# Altium schematic sheet PSU + RTC.SchDoc("PSU + RTC")
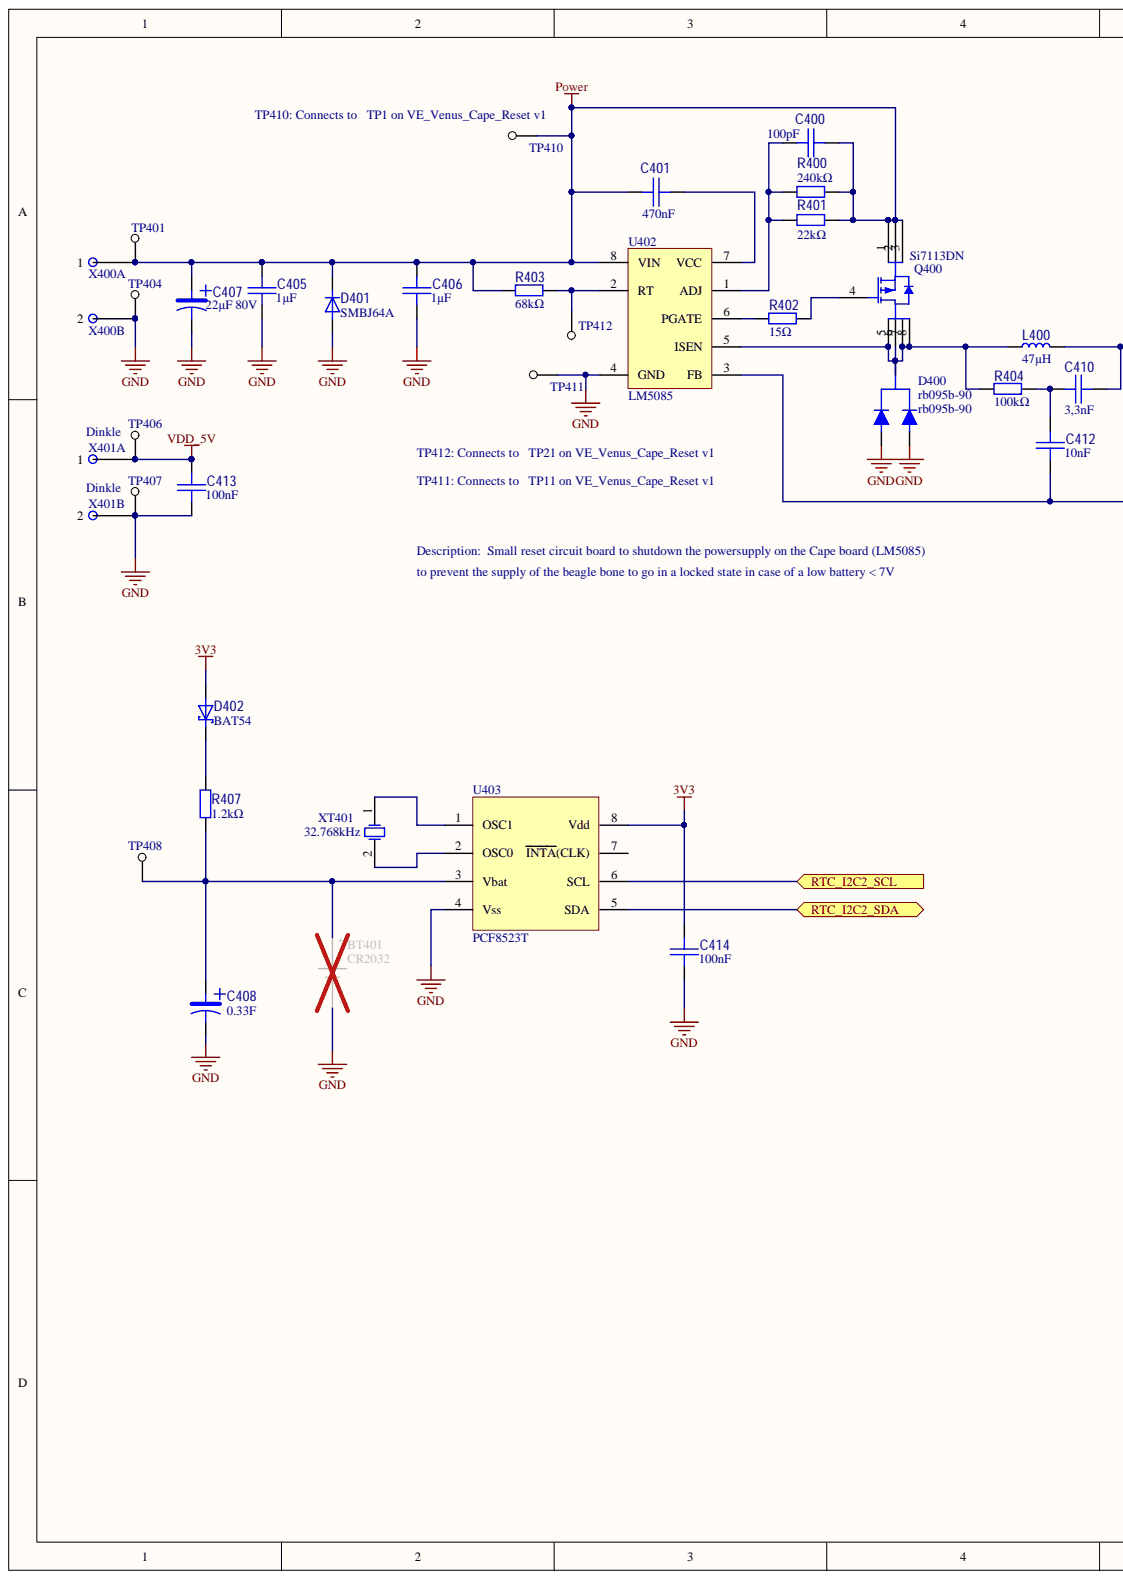
[diagram p0: PSU + RTC.SchDoc, left]
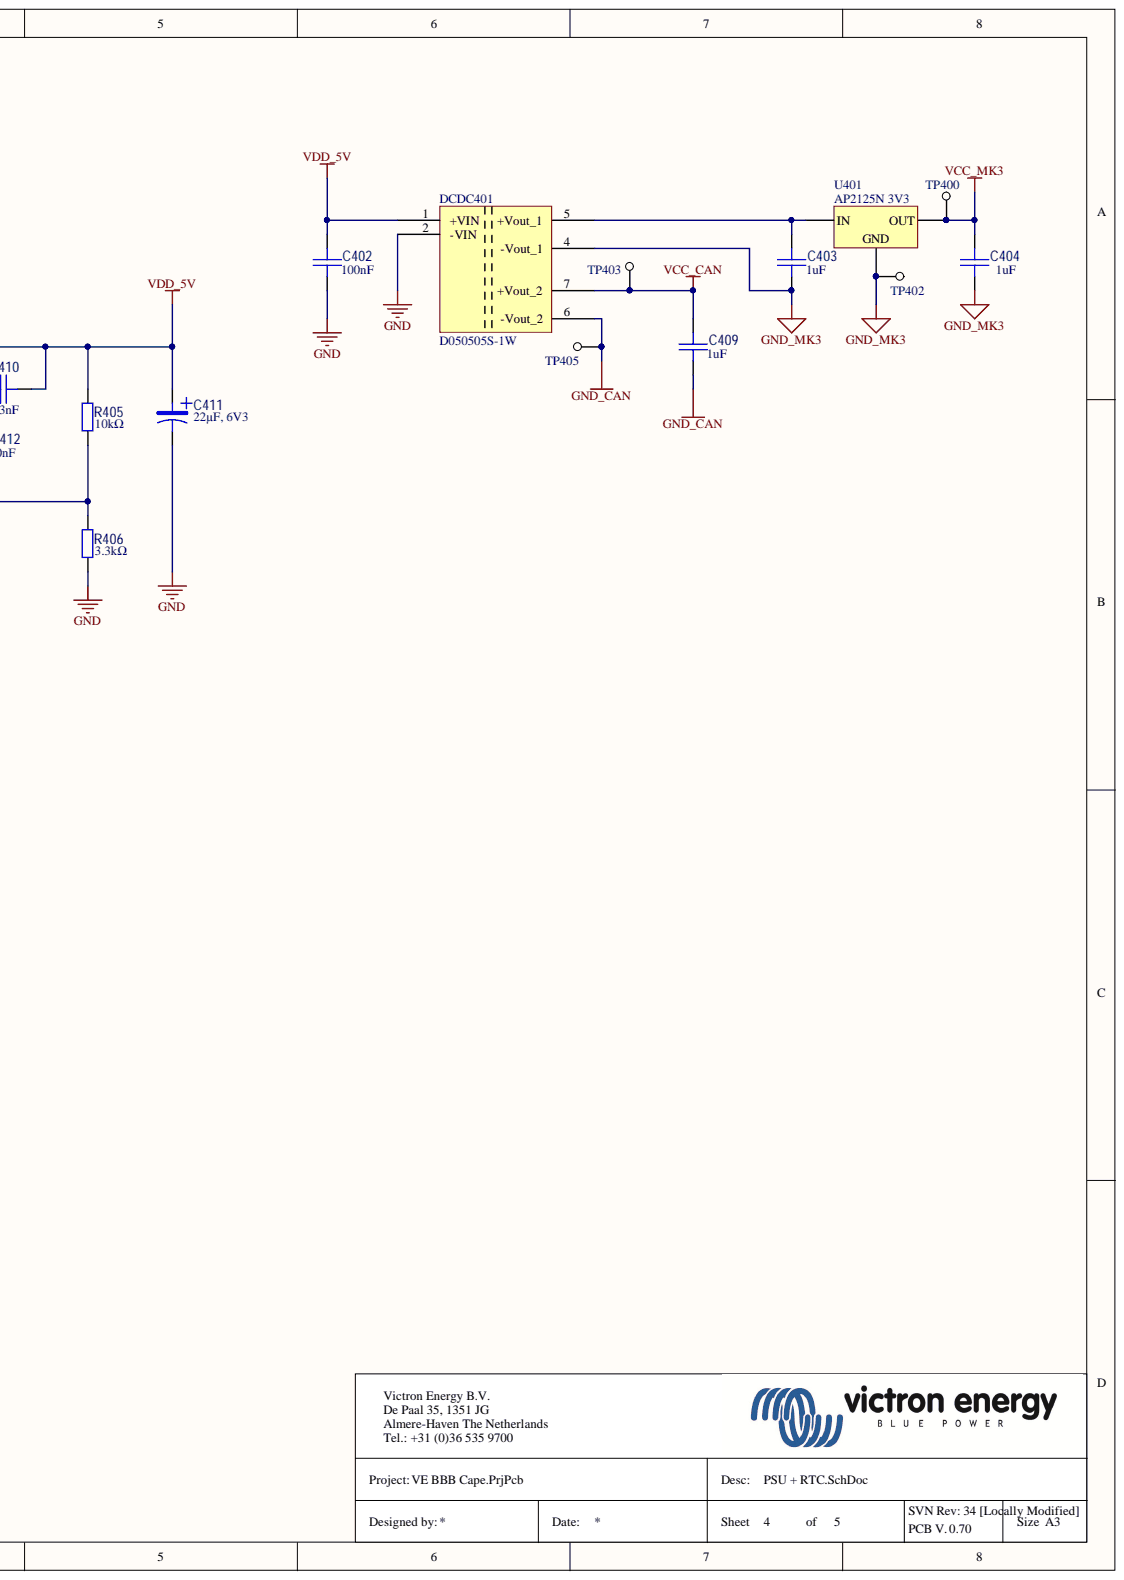
[diagram p1: PSU + RTC.SchDoc, right]

## Parts
BT401  CR2032  [CR2032HFN]
C400  value 100pF  [C0805_R]  (hidden comment: 100419)
C401  value 470nF  [C0805_R]  (hidden comment: 1044)
C402  value 100nF  [C0805_R]  (hidden comment: 1035)
C403  value 1uF  [C0805_R]  (hidden comment: 100185)
C404  value 1uF  [C0805_R]  (hidden comment: 100185)
C405  value 1µF  [C1206_R]  (hidden comment: 100237)
C406  value 1µF  [C1206_R]  (hidden comment: 100237)
C407  value 22µF 80V  [ELCO_8X10_V]  (hidden comment: 100401)
C408  value 0.33F  [033F5V10x5]  (hidden comment: 101670)
C409  value 1uF  [C0805_R]  (hidden comment: 100185)
C410  value 3,3nF  [C0805_R]  (hidden comment: 1082)
C411  value 22µF, 6V3  [ELCO_6.3X5.8_V]  (hidden comment: 100402)
C412  value 10nF  [C0805_R]  (hidden comment: 100420)
C413  value 100nF  [C0805_R]  (hidden comment: 1035)
C414  value 100nF  [C0805_R]  (hidden comment: 1035)
D400  rb095b-90  [TO252_R]
D401  value SMBJ64A  [SMB/DO-214AA]  (hidden comment: 4014)
D402  BAT54  [SOT23_R]
DCDC401  value D050505S-1W  [Recom SIP DCDC 1W]  (hidden comment: D_S-1W)
L400  value 47µH  [WE-PD-XXL]  (hidden comment: 101828)
Q400  Si7113DN  [PowerPak 1212-8]
R400  value 240kΩ  [R0805_R]  (hidden comment: 429)
R401  value 22kΩ  [R0805_R]  (hidden comment: 404)
R402  value 15Ω  [R0805_R]  (hidden comment: 328)
R403  value 68kΩ  [R0805_R]  (hidden comment: 416)
R404  value 100kΩ  [R0805_R]  (hidden comment: 420)
R405  value 10kΩ  [R0805_R]  (hidden comment: 396)
R406  value 3.3kΩ  [R0805_R]  (hidden comment: 384)
R407  value 1.2kΩ  [R0805_R]  (hidden comment: 374)
TP400  CN1  [testpad_round_1mm]  (value not drawn)  (no BOM)
TP401  CN1  [testpad_round_1mm]  (value not drawn)  (no BOM)
TP402  CN1  [testpad_round_1mm]  (value not drawn)  (no BOM)
TP403  CN1  [testpad_round_1mm]  (value not drawn)  (no BOM)
TP404  CN1  [testpad_round_1mm]  (value not drawn)  (no BOM)
TP405  CN1  [testpad_round_1mm]  (value not drawn)  (no BOM)
TP406  CN1  [testpad_round_1mm]  (value not drawn)  (no BOM)
TP407  CN1  [testpad_round_1mm]  (value not drawn)  (no BOM)
TP408  CN1  [testpad_round_1mm]  (value not drawn)  (no BOM)
TP410  CN1  [testpad_round_1mm]  (value not drawn)  (no BOM)
TP411  CN1  [testpad_round_1mm]  (value not drawn)  (no BOM)
TP412  CN1  [testpad_round_1mm]  (value not drawn)  (no BOM)
U401  value AP2125N 3V3  [SOT23_R]  (hidden comment: regulator)
U402  LM5085  [HVSSOP-8]
U403  PCF8523T  [SO8_R]
X400  pluggable terminal 2P  [2EHDRC-2P]  (value not drawn)  (parts A,B)
X401  value Dinkle  [ECH350R-2P]  (hidden comment: 2 pin terminal)  (parts A,B)
XT401  32.768kHz  [ABRA-ABS07-2_V]

## Ports
RTC_I2C2_SCL, RTC_I2C2_SDA

## Nets
3V3: C414.1 D402.1 U403.8(Vdd)
GND: BT401.1 C402.2 C405.2 C406.2 C407.2 C408.2 C411.2 C413.2 C414.2 D400.1 D400.3 D401.2 DCDC401.2(-VIN) R406.2 TP404.1 TP407.1 TP411.1 U402.4(GND) U403.4(Vss) X400.2 X401.2
GND_CAN: C409.2 DCDC401.6(-Vout_2) TP405.1
GND_MK3: C403.2 C404.2 DCDC401.4(-Vout_1) TP402.1 U401.1
Power: C400.2 C401.1 C405.1 C406.1 C407.1 D401.1 Q400.1 Q400.2 Q400.3 R400.2 R401.2 R403.2 TP401.1 TP410.1 U402.8(VIN) X400.1
VCC_CAN: C409.1 DCDC401.7(+Vout_2) TP403.1
VCC_MK3: C404.1 TP400.1 U401.2
VDD_5V: C402.1 C410.2 C411.1 C413.1 DCDC401.1(+VIN) L400.1 R405.1 TP406.1 X401.1
RTC_I2C2_SCL: U403.6(SCL) ; port RTC_I2C2_SCL
RTC_I2C2_SDA: U403.5(SDA) ; port RTC_I2C2_SDA
NetBT401_0: BT401.0 C408.1 R407.1 TP408.1 U403.3(Vbat)
NetC400_1: C400.1 R400.1 R401.1 U402.1(ADJ)
NetC401_2: C401.2 U402.7(VCC)
NetC403_1: C403.1 DCDC401.5(+Vout_1) U401.3
NetC410_1: C410.1 C412.2 R404.2
NetC412_1: C412.1 R405.2 R406.1 U402.3(FB)
NetD400_4: D400.4 L400.2 Q400.5 Q400.6 Q400.7 Q400.8 R404.1 U402.5(ISEN)
NetD402_3: D402.3 R407.2
NetQ400_4: Q400.4 R402.1
NetR402_2: R402.2 U402.6(PGATE)
NetR403_1: R403.1 TP412.1 U402.2(RT)
NetU403_1: U403.1(OSC1) XT401.1
NetU403_2: U403.2(OSC0) XT401.2

Not connected: U403 7(INTA(CLK))

## Library data of the parts
BT401 = 101562: CR2032 solder pins; MANUFACTURER: Panasonic; Database Table Name: Mechanical; Cls: MECH; Value: CR2032; Supplier Price 1: 0,608; Supplier Part Number 1: P666-ND; Supplier 1: Digikey; PartID: 101562; Part: 101562; MAN_PARTNUMBER: CR2032HFN; Component Kind: Standard; Library Name: VictronAltiumComponents.SVNDBLib
C400 = 100419: Part_Description: CAP,100PF,100V,0805,NP0; MANUFACTURER: KEMET; MAN_PARTNUMBER: C0805C101J1GACTU; Voltage: 100V; VENDOR_STOCK_NR: 399-1123-2-ND; VENDOR_PRICE: 0.01426; VENDOR: Digikey; Tol: 0.05; Shape: 805; Database Table Name: COMPDATA$; Cls: CAP; Part: 100419; Component Kind: Standard; Library Name: Data Base Librarys.DbLib
C401 = 1044: Part_Description: Cap, Smd Ml.Ceramic 0805 470NF 10% 50V X7R, Yageo; MANUFACTURER: Yageo; MAN_PARTNUMBER: CC0805KKX7R9BB474; INDIC_PARTNO: CSEC470NFH0KFYAY; Voltage: 50V; VENDOR_STOCK_NR: 311-1889-1-ND; VENDOR_PRICE: 0.05409; VENDOR: Digikey; Tol: 0.1; Shape: 805; INDIC_PRICE: 0.0315; Database Table Name: COMPDATA$; Cls: CAP; Part: 1044; Component Kind: Standard; Library Name: Data Base Librarys.DbLib
C402 C413 C414 = 1035: Cap, SMD 0805, ceramic, X7R, 100nF, 50V, 10%, Yageo; MAN_PARTNUMBER: CC0805KPX7R9BB104; INDIC_PARTNO: CSE100NF0805YAGY; Tol: 10,00%; Shape: 805; INDIC_PRICE: 0,068; Database Table Name: AllComponents; Voltage: 50V; Supplier Price 1: 0,005; Supplier Part Number 1: 603-CC805KPX7R9BB104; Supplier 1: Mouser; PartID: 1035; Part: 1035; MANUFACTURER: Yageo; Component Kind: Standard; Cls: CAP; Library Name: VictronAltiumComponents.SVNDBLib
C403 C404 C409 = 100185: Part_Description: Cap, MLCC, X7R, 16V, 10%, 0805, 1uF; MANUFACTURER: Kemet; MAN_PARTNUMBER: C0805C105K4RACTU; VENDOR_STOCK_NR: 399-1284-1-ND; VENDOR_PRICE: 0.0222; VENDOR: Digikey; Database Table Name: COMPDATA$; Cls: CAP; Part: 100185; Component Kind: Standard; Library Name: Data Base Librarys.DbLib
C405 C406 = 100237: Part_Description: CAP, MLCC, X7R, 1UF, 100V, 1206; MANUFACTURER: Kemet; MAN_PARTNUMBER: C1206C105K1RACTU; VENDOR_STOCK_NR: 399-11683-1-ND; VENDOR_PRICE: 0.13915; VENDOR: Digikey; Shape: 1206; Database Table Name: COMPDATA$; Cls: CAP; Part: 100237; Component Kind: Standard; Library Name: Data Base Librarys.DbLib
C407 = 100401: Part_Description: Cap polarized, hybrid polymer. 80V 22µF; MANUFACTURER: Panasonic; MAN_PARTNUMBER: EEH-ZA1K220P; VENDOR_STOCK_NR: 667-EEH-ZA1K220P; VENDOR_PRICE: 0.958; VENDOR: Mouser; Database Table Name: COMPDATA$; Cls: CAP; Part: 100401; Component Kind: Standard; Library Name: Data Base Librarys.DbLib
C408 = 101670: Cap, Super cap, 0.33F; MANUFACTURER: Panasonic; MAN_PARTNUMBER: EECS0HD334V; Database Table Name: Capacitor; Supplier Price 1: 0,46; Shape: 033F5V10x5; PartID: 101670; Part: 101670; Component Kind: Standard; Cls: CAP; Library Name: VictronAltiumComponents.SVNDBLib
C410 = 1082: Part_Description: CAP,3.3nF,100V, 0805; MANUFACTURER: Yageo; MAN_PARTNUMBER: C0805KRX7R0BB332; INCAP_PARTNO: 1005520681; VENDOR_STOCK_NR: 311-1471-2-ND; VENDOR_PRICE: 0.01802; VENDOR: Digikey; Shape: 805; INCAP_PRICE: 0.0032; Database Table Name: COMPDATA$; Cls: CAP; Part: 1082; Component Kind: Standard; Library Name: Data Base Librarys.DbLib
C411 = 100402: Part_Description: Cap polarized, polymer. 6.3V 220µF; MANUFACTURER: Panasonic; MAN_PARTNUMBER: 6SVP220M; VENDOR_STOCK_NR: 667-6SVP220M; VENDOR_PRICE: 0.339; VENDOR: Mouser; Database Table Name: COMPDATA$; Cls: CAP; Part: 100402; Component Kind: Standard; Library Name: Data Base Librarys.DbLib
C412 = 100420: Part_Description: CAP,10nF,MLC X7R 50V,0805; MANUFACTURER: KEMET; MAN_PARTNUMBER: C0805C101K5RACTU; Voltage: 50V; VENDOR_STOCK_NR: 399-7981-2-ND; VENDOR_PRICE: 0.038; VENDOR: Digikey; Tol: 0.1; Shape: 805; Database Table Name: COMPDATA$; Cls: CAP; Part: 100420; Component Kind: Standard; Library Name: Data Base Librarys.DbLib
D400 = 101551: MANUFACTURER: Rohm; Shape: TO 252 Dpak; Database Table Name: AllComponents; Cls: DIO; Value: rb095b-90; Supplier Price 1: 0,3598; Supplier Part Number 1: RB095B-90TLTR-ND; Supplier 1: Digikey; PartID: 101551; Part: 101551; MAN_PARTNUMBER: rb095b-90; Description: rb095b-90; Component Kind: Standard; Library Name: VictronAltiumComponents.SVNDBLib
D401 = 4014: Part_Description: Diode,SMD ,2A 100V, ES2B, SMB; MANUFACTURER: Vishay; MAN_PARTNUMBER: ES2B; VENDOR_STOCK_NR: 9549250; VENDOR_PRICE: 0.154; VENDOR: Farnell; Database Table Name: COMPDATA$; Cls: DIO; Part: 4014; Component Kind: Standard; Library Name: Data Base Librarys.DbLib
D402 = 4002: Part_Description: DIODE,30V 0.2A,SOT23DDCK,BAT54; MANUFACTURER: NXP; INDIC_PARTNO: DDSMDSOTBAT54NXY; VENDOR_STOCK_NR: 1081190; VENDOR_PRICE: 0.034; VENDOR: Farnell; INDIC_PRICE: 0.0806; Database Table Name: COMPDATA$; Cls: DIO; Value: BAT54; Part: 4002; MAN_PARTNUMBER: BAT54; Component Kind: Standard; Library Name: Data Base Librarys.DbLib
DCDC401 = 101516: IC, controller, buck, 5V, 100mA, Mornsun unregulated twin DC-DC Converter; Shape: TH; Database Table Name: AllComponents; Supplier Price 1: 2,28; PartID: 101516; Part: 101516; MANUFACTURER: Mornsun; MAN_PARTNUMBER: D050505S-1W; Component Kind: Standard; Cls: IC; Library Name: VictronAltiumComponents.SVNDBLib
L400 = 101828: Choke SMD 47µH; MANUFACTURER: Bourns; MAN_PARTNUMBER: SRR1210A-470M; Shape: TH; Database Table Name: Inductor; Cls: IND; Supplier Price 1: 0,51870; Supplier Part Number 1: SRR1210A-470MCT-ND; Supplier 1: Digikey; PartID: 101828; Part: 101828; Component Kind: Standard; Library Name: VictronAltiumComponents.SVNDBLib
Q400 = 100400: Part_Description: PMOS, SI7113DN, -100V 134mOhm, PowerPAK SO8; MANUFACTURER: Vishay Siliconix; MAN_PARTNUMBER: SI7113DN-T1-GE3; VENDOR_STOCK_NR: 781-SI7113DN-T1-GE3; VENDOR_PRICE: 0.669; VENDOR: Mouser; Database Table Name: COMPDATA$; Cls: FET; Value: Si7113DN; Part: 100400; Component Kind: Standard; Library Name: Data Base Librarys.DbLib
R400 = 429: Part_Description: RES,MF,240K, 0.125W 1% 0805; MANUFACTURER: YAGEO; MAN_PARTNUMBER: RC0805FR-07240KL; VENDOR_STOCK_NR: 603-RC0805FR-07240KL; VENDOR_PRICE: 0.003; VENDOR: Mouser; Tol: 0.01; Shape: 805; Power: 0,125W; INCAP_PRICE: 0.0014; Database Table Name: COMPDATA$; Cls: RES; Part: 429; ComponentLink1Description: \datasheets\Yageo-RC0805FR-0710KL-datasheet.pdf; Component Kind: Standard; Library Name: Data Base Librarys.DbLib
R401 = 404: Part_Description: RES,MF,22K   0.125W 1% 0805; MANUFACTURER: YAGEO; MAN_PARTNUMBER: RC0805FR-0722KL; INDIC_PARTNO: RSCEE0022KF97MUY; INCAP_PARTNO: 1035031293; VENDOR_STOCK_NR: 603-RC0805FR-0722KL; VENDOR_PRICE: 0.003; VENDOR: Mouser; Tol: 0.01; Shape: 805; Power: 0,125W; INDIC_PRICE: 0.0023; INCAP_PRICE: 0.0014; Database Table Name: COMPDATA$; Cls: RES; Part: 404; ComponentLink1Description: \datasheets\Yageo-RC0805FR-0710KL-datasheet.pdf; Component Kind: Standard; Library Name: Data Base Librarys.DbLib
R402 = 328: Res, SMD 0805, MF, 15E, 0.125W 1% 0805; MANUFACTURER: YAGEO; Tol: 1,00%; Shape: 0805; Power: 0,125W; INCAP_PRICE: 0,0009; Database Table Name: Resistor; PartID: 328; Part: 328; ComponentLink1Description: \datasheets\Yageo-RC0805FR-0710KL-datasheet.pdf; Component Kind: Standard; Cls: RES; Library Name: VictronAltiumComponents.SVNDBLib
R403 = 416: Part_Description: RES,MF,68K, 0.125W 1% 0805; MANUFACTURER: YAGEO; MAN_PARTNUMBER: RC0805FR-0768KL; INDIC_PARTNO: RSCE68KXXFB8NYX; VENDOR_STOCK_NR: 603-RC0805FR-0768KL; VENDOR_PRICE: 0.003; VENDOR: Mouser; Tol: 0.01; Shape: 805; Power: 0,125W; INDIC_PRICE: 0.0018; INCAP_PRICE: 0.0014; Database Table Name: COMPDATA$; Cls: RES; Part: 416; ComponentLink1Description: \datasheets\Yageo-RC0805FR-0710KL-datasheet.pdf; Component Kind: Standard; Library Name: Data Base Librarys.DbLib
R404 = 420: Res, SMD 0805, MF, 100K 0.125W 1% 0805; MANUFACTURER: YAGEO; MAN_PARTNUMBER: RC0805FR-07 100KL; INDIC_PARTNO: RSCE100KXFB8NYX; INCAP_PARTNO: 1035031298; Tol: 1,00%; Shape: 0805; Power: 0,125W; INDIC_PRICE: 0,0008; INCAP_PRICE: 0,0009; Database Table Name: Resistor; PartID: 420; Part: 420; ComponentLink1Description: \datasheets\Yageo-RC0805FR-0710KL-datasheet.pdf; Component Kind: Standard; Cls: RES; Library Name: VictronAltiumComponents.SVNDBLib
R405 = 396: Part_Description: RES,MF,10K  0.125W 1%0805; MANUFACTURER: YAGEO; MAN_PARTNUMBER: RC0805FR-0710KL; INDIC_PARTNO: RSCE00010KFB7YAY; INCAP_PARTNO: 1035031290; VENDOR_STOCK_NR: 603-RC0805FR-0710KL; VENDOR_PRICE: 0.003; VENDOR: Mouser; Tol: 0.01; Shape: 805; Power: 0,125W; INDIC_PRICE: 0.0018; INCAP_PRICE: 0.0014; Database Table Name: COMPDATA$; Cls: RES; Part: 396; ComponentLink1Description: \datasheets\Yageo-RC0805FR-0710KL-datasheet.pdf; Component Kind: Standard; Library Name: Data Base Librarys.DbLib
R406 = 384: Part_Description: RES,MF,3K3, 0.125W 1% 0805; MANUFACTURER: YAGEO; MAN_PARTNUMBER: RC0805FR-073K3L; INDIC_PARTNO: RSCEO3K300FB6YAY; VENDOR_STOCK_NR: 603-RC0805FR-073K3L; VENDOR_PRICE: 0.003; VENDOR: Mouser; Tol: 0.01; Shape: 805; Power: 0,125W; INDIC_PRICE: 0.0076; INCAP_PRICE: 0.0014; Database Table Name: COMPDATA$; Cls: RES; Part: 384; ComponentLink1Description: \datasheets\Yageo-RC0805FR-0710KL-datasheet.pdf; Component Kind: Standard; Library Name: Data Base Librarys.DbLib
R407 = 374: Part_Description: RES,MF,1k2, 0.125W 1% 0805; MANUFACTURER: YAGEO; MAN_PARTNUMBER: RC0805FR-071K2L; VENDOR_STOCK_NR: 603-RC0805FR-071K2L; VENDOR_PRICE: 0.003; VENDOR: Mouser; Tol: 0.01; Shape: 805; Power: 0,125W; INCAP_PRICE: 0.0014; Database Table Name: COMPDATA$; Cls: RES; Part: 374; ComponentLink1Description: \datasheets\Yageo-RC0805FR-0710KL-datasheet.pdf; Component Kind: Standard; Library Name: Data Base Librarys.DbLib
TP400-TP408 TP410-TP412 = 100320: Testpad, Round, D=1mm; Database Table Name: Connector; Cls: CON; PartID: 100320; Part: 100320; Library Name: VictronAltiumComponents.SVNDBLib
U401 = 100431: Part_Description: LDO Regulator 3V3; MANUFACTURER: Diodes Inc.; MAN_PARTNUMBER: AP2125N-3.3TRG1; VENDOR_STOCK_NR: 621-AP2125N-3.3TRG1; VENDOR_PRICE: 0.077; VENDOR: Mouser; Database Table Name: COMPDATA$; Cls: IC; Part: 100431; Component Kind: Standard; Library Name: Data Base Librarys.DbLib
U402 = 101556: IC, controller, buck, 75V; MANUFACTURER: TI; MAN_PARTNUMBER: LM5085MY/NOPB; Value: LM5085; Supplier Price 1: 0,95625; Supplier Part Number 1: LM5085MY/NOPBCT-ND; Supplier 1: Digikey; Shape: HVSSOP-8; PartID: 101556; Part: 101556; Database Table Name: IC; Component Kind: Standard; Cls: IC; Library Name: VictronAltiumComponents.SVNDBLib
U403 = 101555: IC, RTC, I2C; MANUFACTURER: NXP; Shape: SOIC8; Database Table Name: AllComponents; Value: PCF8523T; Supplier Price 1: 0,58590; Supplier Part Number 1: 568-5306-1-ND; Supplier 1: Digikey; PartID: 101555; Part: 101555; MAN_PARTNUMBER: PCF8523T; Component Kind: Standard; Cls: IC; Library Name: VictronAltiumComponents.SVNDBLib
X400 = 101569: 2EHDRC-2P; MANUFACTURER: 2EHDRC-2P + 2ESDV-2P; Database Table Name: AllComponents; Cls: CON; PartID: 101569; Part: 101569; Component Kind: Standard; Library Name: VictronAltiumComponents.SVNDBLib
X401 = 100409: Part_Description: 2 pin Pluggable terminal Dinkle ECH350R; VENDOR_PRICE: 0.4261; VENDOR: ASTA TECHNOLOGIES; Database Table Name: COMPDATA$; Cls: CON; Part: 100409; MANUFACTURER: Dinkle; MAN_PARTNUMBER: ECH350R-2P; Component Kind: Standard; Library Name: Data Base Librarys.DbLib
XT401 = 100432: Crystal Unit; Part_Description: CRYSTAL, 32.768KHZ, 9PF, SMD; MANUFACTURER: ABRACON; MAN_PARTNUMBER: ABS07-32.768KHZ-9-T; VENDOR_STOCK_NR: 815-ABS07-32.768K9T; VENDOR_PRICE: 0.383; VENDOR: Mouser; Database Table Name: COMPDATA$; Cls: XTAL; Value: 32.768kHz; Part: 100432; Component Kind: Standard; 2nd supplier price: 0.39; 2nd supplier part no#: ABS07-32.768KHZ-9-T; 2nd supplier: AVNET; Library Name: Data Base Librarys.DbLib
